# Altium schematic sheet RuggedBatteryPackV3-InputStage.SchDoc
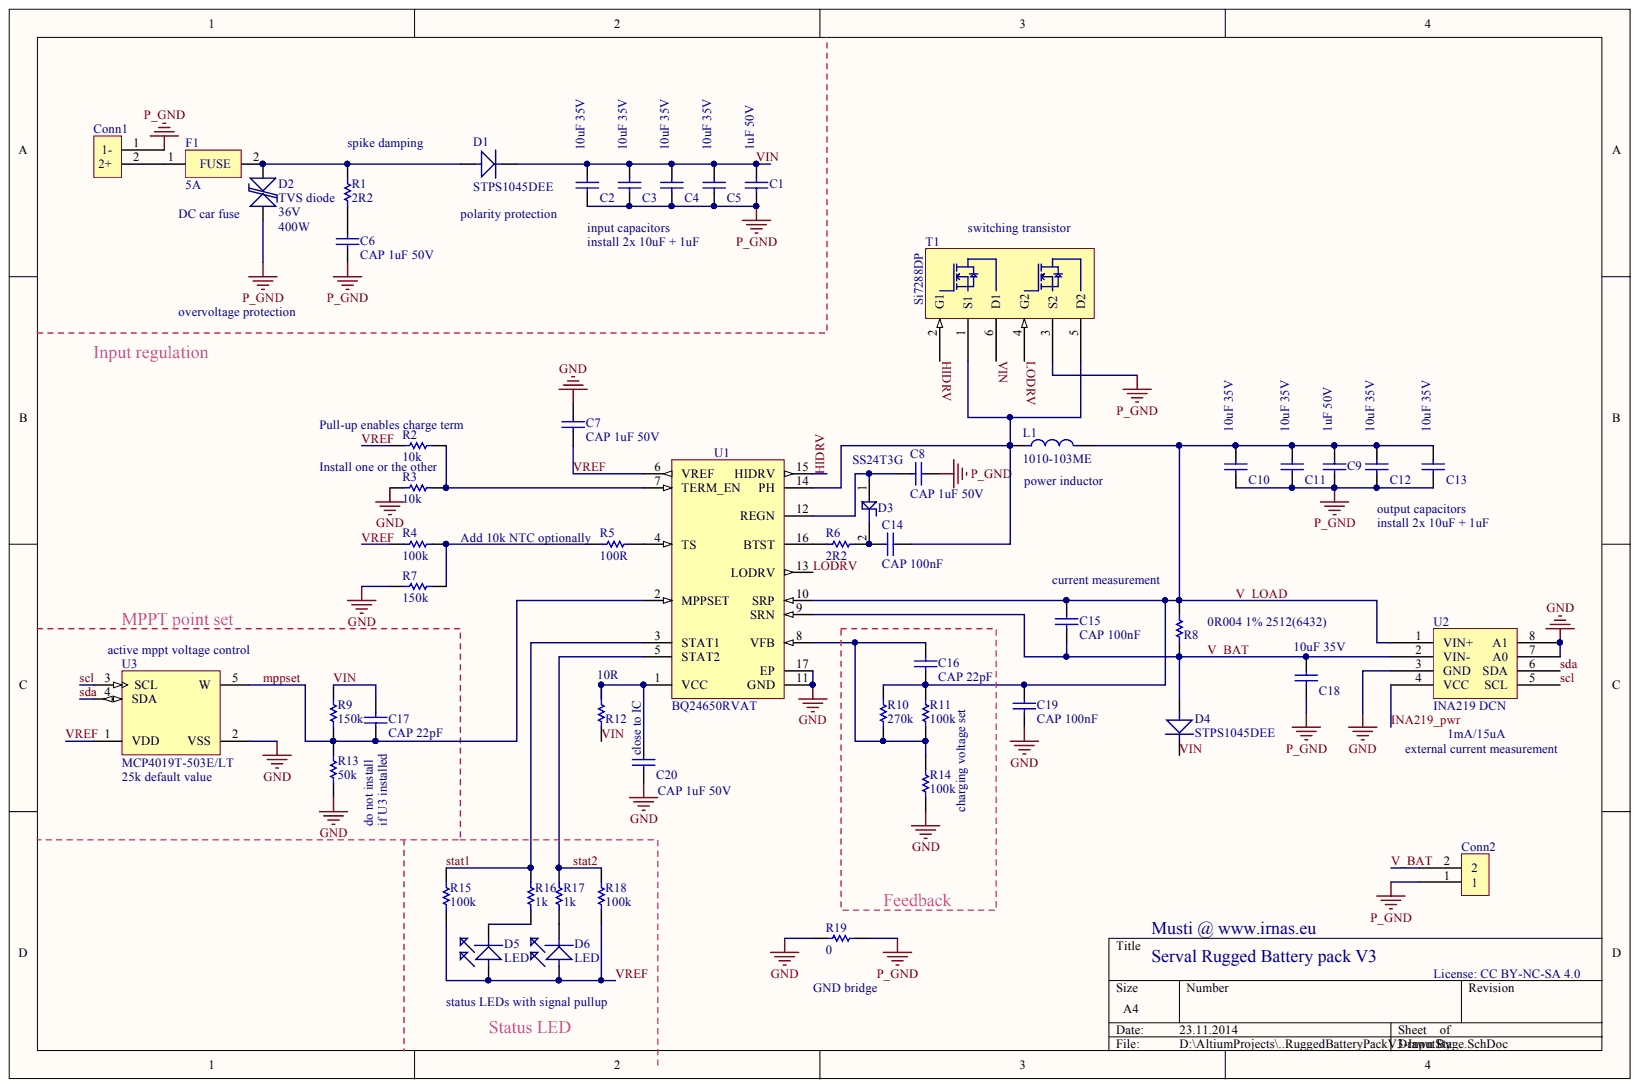
[diagram p0: RuggedBatteryPackV3-InputStage.SchDoc]

## Parts
C1  1uF 50V  value 1uF  [CAPC0805(2012)145_L]
C2  10uF 35V  value 10uF  [1206]
C3  10uF 35V  value 10uF  [1206]
C4  10uF 35V  value 10uF  [1206]
C5  10uF 35V  value 10uF  [1206]
C6  CAP 1uF 50V  value 1uF  [CAPC0805(2012)145_L]
C7  CAP 1uF 50V  value 1uF  [CAPC0805(2012)145_L]
C8  CAP 1uF 50V  value 1uF  [CAPC0805(2012)145_L]
C9  1uF 50V  value 1uF  [CAPC0805(2012)145_L]
C10  10uF 35V  value 10uF  [1206]
C11  10uF 35V  value 10uF  [1206]
C12  10uF 35V  value 10uF  [1206]
C13  10uF 35V  value 10uF  [1206]
C14  CAP 100nF  value 100nF  [0603]
C15  CAP 100nF  value 100nF  [0603]
C16  CAP 22pF  [0603]
C17  CAP 22pF  [0603]
C18  10uF 35V  value 10uF  [1206]
C19  CAP 100nF  value 100nF  [0603]
C20  CAP 1uF 50V  value 1uF  [CAPC0805(2012)145_L]
Conn1  PowerScrewTerminal  [MKDSN 2,5/ 2-5,08 and powercon]  (value not drawn)
Conn2  PowerScrewTerminal  [MKDSN 2,5/ 2-5,08]  (value not drawn)
D1  STPS1045DEE  [PowerFLAT-3.3x3.3-8]
D2  TVS diode  Power: 400W  Voltage: 36V  [SMB]
D3  SS24T3G  [SOT23]
D4  STPS1045DEE  [PowerFLAT-3.3x3.3-8]
D5  LED  [0603]
D6  LED  [0603]
F1  5A  [FUSE CLIP 3550]
L1  1010-103ME  [XAL1010-coilcraft]
R1  2R2  [RESC0603(1608)_L]
R2  10k  [RESC0603(1608)_L]
R3  10k  [RESC0603(1608)_L]
R4  100k  [RESC0603(1608)_L]
R5  100R  [RESC0603(1608)_L]
R6  2R2  [RESC0603(1608)_L]
R7  150k  [RESC0603(1608)_L]
R8  0R004 1% 2512(6432)  [RESC2512(6432)_M]
R9  150k  [RESC0603(1608)_L]
R10  270k  [RESC0603(1608)_L]
R11  100k  [RESC0603(1608)_L]
R12  10R  [RESC0603(1608)_L]
R13  50k  [RESC0603(1608)_L]
R14  100k  [RESC0603(1608)_L]
R15  100k  [RESC0603(1608)_L]
R16  1k  [RESC0603(1608)_L]
R17  1k  [RESC0603(1608)_L]
R18  100k  [RESC0603(1608)_L]
R19  0  [RESC0603(1608)_L]
T1  Si7288DP  [PowerPAK SO-8]
U1  BQ24650RVAT  [RVA16-2140X2140TP]
U2  INA219 DCN  [DCN-SOT23-8]
U3  MCP4019T-503E/LT  [SC70-LT5_M]

## Nets
GND: C7.1 C19.2 C20.1 R3.1 R7.1 R13.2 R14.1 R19.2 U1.11(GND) U1.17(EP) U2.3(GND) U2.7(A0) U2.8(A1) U3.2(VSS)
P_GND: C1.2 C2.1 C3.1 C4.1 C5.1 C6.2 C8.1 C9.1 C10.1 C11.1 C12.1 C13.1 C18.1 Conn1.1 Conn2.1 D2.1 R19.1 T1.3(S2)
HIDRV: T1.2(G1) U1.15(HIDRV)
INA219_PWR: U2.4(VCC)
LODRV: T1.4(G2) U1.13(LODRV)
MPPSET: C17.1 R9.1 R13.1 U1.2(MPPSET) U3.5(W)
SCL: U2.5(SCL) U3.3(SCL)
SDA: U2.6(SDA) U3.4(SDA)
STAT1: R15.2 R16.2 U1.3(STAT1)
STAT2: R17.2 R18.2 U1.5(STAT2)
V_BAT: C15.2 C18.2 Conn2.2 D4.1 R8.1 U1.9(SRN) U2.2(VIN-)
V_LOAD: C9.2 C10.2 C11.2 C12.2 C13.2 C15.1 C16.1 C19.1 L1.2 R8.2 R10.2 R11.2 U1.10(SRP) U2.1(VIN+)
VIN: C1.1 C2.2 C3.2 C4.2 C5.2 C17.2 D1.3 D4.3 R9.2 R12.1 T1.6(D1)
VREF: C7.2 D5.1 D6.1 R2.1 R4.2 R15.1 R18.1 U1.6(VREF) U3.1(VDD)
NetC6_1: C6.1 R1.2
NetC8_2: C8.2 D3.1 U1.12(REGN)
NetC14_1: C14.1 D3.2 R6.1
NetC14_2: C14.2 L1.1 T1.1(S1) T1.5(D2) U1.14(PH)
NetC16_2: C16.2 R10.1 R11.1 R14.2 U1.8(VFB)
NetC20_2: C20.2 R12.2 U1.1(VCC)
NetConn1_2: Conn1.2 F1.1
NetD1_1: D1.1 D2.2 F1.2 R1.1
NetD5_2: D5.2 R16.1
NetD6_2: D6.2 R17.1
NetR2_2: R2.2 R3.2 U1.7(TERM_EN)
NetR4_1: R4.1 R5.2 R7.2
NetR5_1: R5.1 U1.4(TS)
NetR6_2: R6.2 U1.16(BTST)

## Library data of the parts
C1 C6-C9 C20 = CMP-1036-04761-1: CAP 1uF 50V ±10% 0805 (2012 Metric) Thickness 1.45mm SMD; Case-EIA: 0805; Case-Metric: 2012; Component Kind: Standard; Max Thickness: 1.45 mm; Rated Voltage: 50 V; Technology: SMT; Tolerance: ±10%
  C1 C6-C9: Library Name: Altium Content Vault
  C20: Library Name: GeneralComponents.SchLib
C2-C5 C10-C13 C18 = CMP-1038-02235-1: CAP 10uF 35V ±20% 1210 (3225 Metric) Thickness 2.1mm SMD; Case-EIA: 1210; Case-Metric: 3225; Component Kind: Standard; Library Name: Altium Content Vault; Max Thickness: 2.1 mm; Rated Voltage: 35 V; Technology: SMT; Tolerance: ±20%
C14-C17 C19 = CMP-1035-02584-1: CAP 100nF 50V ±10% 0603 (1608 Metric) Thickness 1mm SMD; Case-Metric: 1608; Rated Voltage: 16 V; Case-EIA: 0603; Component Kind: Standard; Library Name: Altium Content Vault; Max Thickness: 1 mm; Technology: SMT; Tolerance: ±10%
  C16 C17: Value: 100nF
D1 D4 = Rectifier_diode: Diode rectifier STPS1045DEE; Component Kind: Standard; Library Name: GeneralComponents.SchLib
D2 = TVS diode: TVS bidirectional diode; Component Kind: Standard; Library Name: GeneralComponents.SchLib
D3 = Schottky diode: Component Kind: Standard; Description: Schottky diode; Library Name: GeneralComponents.SchLib
D5 D6 = LED: LED diode; Component Kind: Standard; Library Name: GeneralComponents.SchLib
F1 = Fuse: 1A fuse; Component Kind: Standard; Library Name: GeneralComponents.SchLib
L1 = Inductor XAL7070-332MEC: Coilcraft XAL; Component Kind: Standard; Library Name: GeneralComponents.SchLib
R1 R5 R6 R19 = CMP-1012-00026-1: 100R 0.1W 5% 0603 (1608 Metric)  SMD; Case-EIA: 0603; Case-Metric: 1608; Value: 10.00 Ohm; Component Kind: Standard; Library Name: Altium Content Vault; Power: 0.1 W; Technology: SMT; Tolerance: 5 %
R2-R4 R7 R12 R15 R18 = CMP-1012-00026-1: 10R 0.1W 5% 0603 (1608 Metric)  SMD; Case-EIA: 0603; Case-Metric: 1608; Value: 10.00 Ohm; Component Kind: Standard; Library Name: Altium Content Vault; Power: 0.1 W; Technology: SMT; Tolerance: 5 %
R8 = CMP-1018-00510-1: 0R004 1W 1% 2512 (6432 Metric)  SMD; Case-EIA: 2512; Case-Metric: 6432; Value: 1000.00 Ohm; Component Kind: Standard; Library Name: Altium Content Vault; Power: 1 W; Technology: SMT; Tolerance: 1 %
R9 R13 = CMP-1012-00026-1: 100k 0.1W 5% 0603 (1608 Metric)  SMD; Case-EIA: 0603; Case-Metric: 1608; Value: 10.00 Ohm; Component Kind: Standard; Library Name: Altium Content Vault; Power: 0.1 W; Technology: SMT; Tolerance: 5 %
R10 R11 R14 R16 R17 = CMP-1012-00026-1: 1k 0.1W 5% 0603 (1608 Metric)  SMD; Case-EIA: 0603; Case-Metric: 1608; Value: 10.00 Ohm; Component Kind: Standard; Library Name: Altium Content Vault; Power: 0.1 W; Technology: SMT; Tolerance: 5 %
T1 = Si7288DP: Vishay Siliconix  Si7288DP  New Product  Document Number: 65366  S09-2113-Rev. A, 12-Oct-09  www.vishay.com  1  Dual N-Channel 40-V (D-S) MOSFET; Component Kind: Standard; Library Name: GeneralComponents.SchLib
U1 = CMP-0321-00203-1: High Efficiency Synchronous Switch-Mode Charger Controller- Solar Battery Charger, 5 V, -40 to 85 degC, 16-pin VQFN (RVA), Green (RoHS & no Sb/Br); Manufacturer: Texas Instruments; Lead Acid: N; SubFamily: Battery Charge Management; Vin(Min)(V): 5; Component Kind: Standard; ComponentLink1Description: Datasheet; ComponentLink1URL: http://www.ti.com/general/docs/lit/getliterature.tsp?genericPartNumber=BQ24650&fileType=pdf; DatasheetVersion: SLUSA75; Eco-Plan: Green (RoHS & no Sb/Br); Li-Ion: N; Li-Polymer: N; Library Name: Altium Content Vault; Mounting Technology: Surface Mount; NiCd: N; NiMH: N; Over Voltage Protection: N; PackageDescription: 16-Pin Plastic Quad Flatpack No-Lead, 3.5 x 3.5 mm Body, 0.5 mm Pitch, Exposed Pad; PackageReference: RVA16-2140X2140TP; PackageVersion: revC, May-2011; PartNumber: BQ24650RVAT
U3 = CMP-0188-00765-2: 7-Bit Single I2C Digital POT with Volatile Memory, 5-pin SC70, Extended Temperature, Tape and Reel; Code_IPC: SOT353-5; Component Kind: Standard; ComponentLink1Description: Manufacturer URL; ComponentLink1URL: http://www.microchip.com/; ComponentLink2Description: Datasheet; ComponentLink2URL: http://ww1.microchip.com/downloads/en/DeviceDoc/22147a.pdf; ComponentLink3Description: Package Specification; ComponentLink3URL: http://www.microchip.com/stellent/groups/techpub_sg/documents/packagingspec/en012702.pdf; DatasheetVersion: revA, Mar-2009; Library Name: Altium Content Vault; PackageDescription: 5-Lead Plastic Small Outline Transistor (LT) [SC70]; PackageReference: SC70-LT5; PackageVersion: revBB, Aug-2009; RevisionNote: URLs added.
Conn1 Conn2 = PowerScrewTerminal: Component Kind: Standard; Library Name: GeneralComponents.SchLib
U2 = INA219 DCN: Component Kind: Standard; Library Name: MSP430.SchLib
